# Altium schematic sheet Icarus Som.SchDoc("Icarus Som")
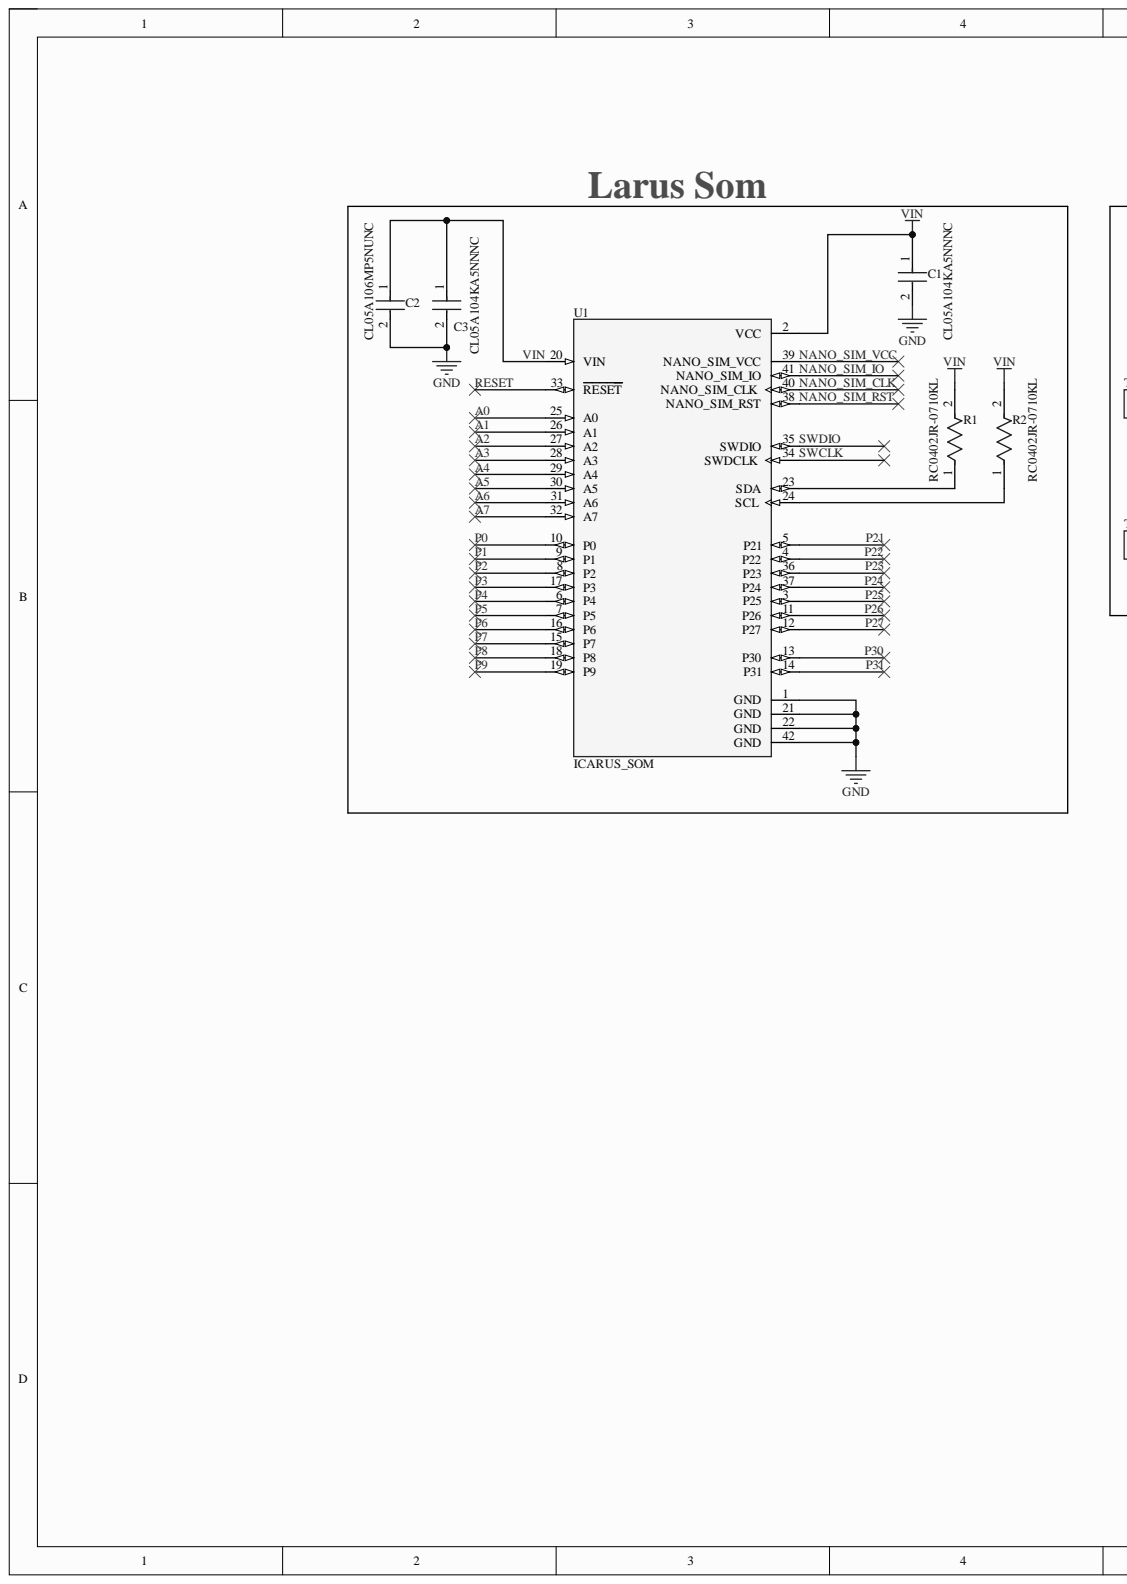
[diagram p0: Icarus Som.SchDoc, left]
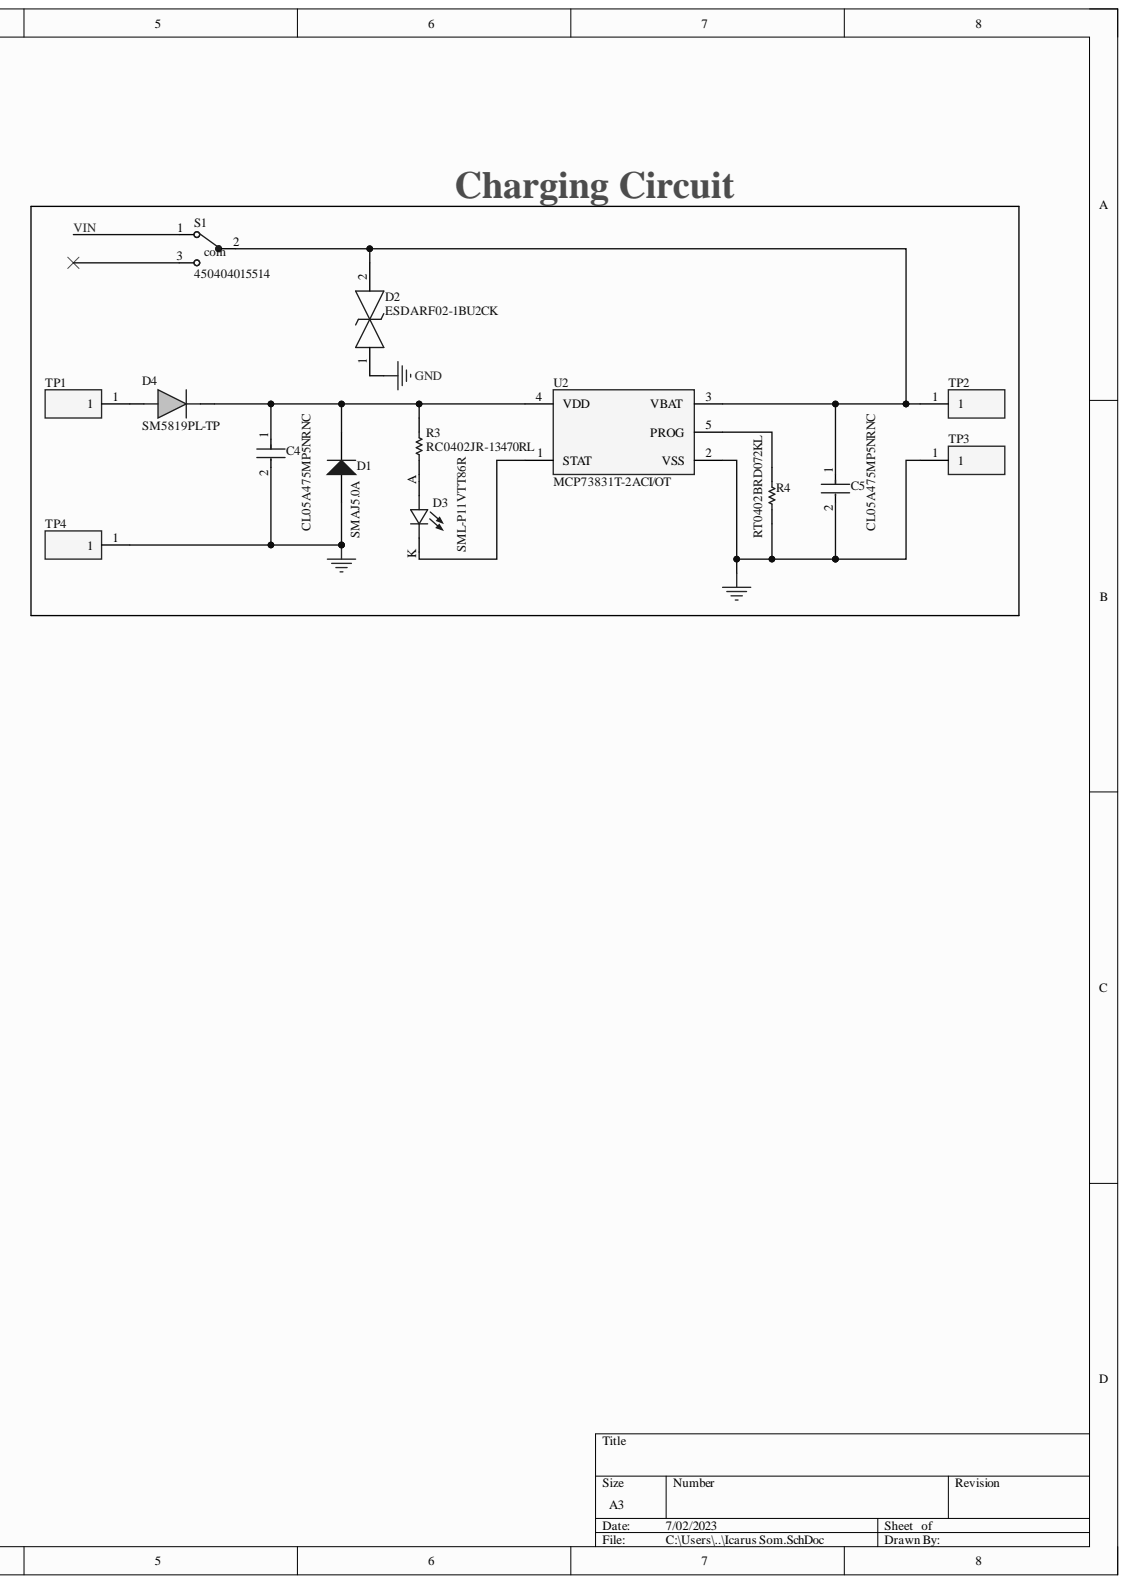
[diagram p1: Icarus Som.SchDoc, right]

## Parts
C1  CL05A104KA5NNNC  [FP-CL278-IPC_A]
C2  CL05A106MP5NUNC  [FP-CL05A106MP5NUNC-MFG]
C3  CL05A104KA5NNNC  [FP-CL278-IPC_A]
C4  CL05A475MP5NRNC  [FP-CL282-IPC_A]
C5  CL05A475MP5NRNC  [FP-CL282-IPC_A]
D1  SMAJ5.0A  [BOUR-SMA-2_V]
D2  ESDARF02-1BU2CK  [ESDARF021BU2CK]
D3  SML-P11VTT86R  [LED_SML-P11VTT86R]
D4  SM5819PL-TP  [SODFL3716X120N]
R1  RC0402JR-0710KL  [FP-RC0402-0_4-IPC_A]
R2  RC0402JR-0710KL  [FP-RC0402-0_4-IPC_A]
R3  RC0402JR-13470RL  [RESC1005X40X25LL05T10]
R4  RT0402BRD072KL  [RESC1005X35X25LL10T10]
S1  450404015514  [450404015514]
TP1  (no value)  [TP+195X110]
TP2  (no value)  [TP+195X110]
TP3  (no value)  [TP+195X110]
TP4  (no value)  [TP+195X110]
U1  ICARUS_SOM  [MODULE_ICARUS_SOM]
U2  MCP73831T-2ACI/OT  [FP-C04-091-OT-IPC_A]

## Nets
GND: C1.2 C2.2 C3.2 C4.2 C5.2 D1.1 D2.1 R4.1 TP3.1 TP4.1 U1.1(GND) U1.21(GND) U1.22(GND) U1.42(GND) U2.2(VSS)
A0: U1.25(A0)
A1: U1.26(A1)
A2: U1.27(A2)
A3: U1.28(A3)
A4: U1.29(A4)
A5: U1.30(A5)
A6: U1.31(A6)
A7: U1.32(A7)
NANO_SIM_CLK: U1.40(NANO_SIM_CLK)
NANO_SIM_IO: U1.41(NANO_SIM_IO)
NANO_SIM_RST: U1.38(NANO_SIM_RST)
NANO_SIM_VCC: U1.39(NANO_SIM_VCC)
P0: U1.10(P0)
P1: U1.9(P1)
P2: U1.8(P2)
P3: U1.17(P3)
P4: U1.6(P4)
P5: U1.7(P5)
P6: U1.16(P6)
P7: U1.15(P7)
P8: U1.18(P8)
P9: U1.19(P9)
P21: U1.5(P21)
P22: U1.4(P22)
P23: U1.36(P23)
P24: U1.37(P24)
P25: U1.3(P25)
P26: U1.11(P26)
P27: U1.12(P27)
P30: U1.13(P30)
P31: U1.14(P31)
RESET: U1.33(RESET)
SWCLK: U1.34(SWDCLK)
SWDIO: U1.35(SWDIO)
VIN: C1.1 C2.1 C3.1 R1.2 R2.2 S1.1 U1.2(VCC) U1.20(VIN)
NetC4_1: C4.1 D1.2 D4.1 R3.2 U2.4(VDD)
NetC5_1: C5.1 D2.2 S1.2 TP2.1 U2.3(VBAT)
NetD3_A: D3.A R3.1
NetD3_K: D3.K U2.1(STAT)
NetD4_2: D4.2 TP1.1
NetR1_1: R1.1 U1.23(SDA)
NetR2_1: R2.1 U1.24(SCL)
NetR4_2: R4.2 U2.5(PROG)

Not connected: S1 3

## Library data of the parts
C1 C3 = CMP-13271-000728-1: Cap Ceramic 100nF 25V X5R ±10% SMD 0402 +85°C Paper T/R; Capacitance: 100nF; Case Code (Imperial): 0402; Case Code (Metric): 1005; Case/Package: 0402; Component Kind: Standard; Depth: 0.5mm; Dielectric: X5R; Height: 0.5mm; Lead Free: Lead Free; Length: 1mm; Max Operating Temperature: 85°C; Min Operating Temperature: -55°C; Mount: Surface Mount; Package Quantity: 1; Packaging: Tape and Reel; Radiation Hardening: No; Thickness: 0.022inch; Tolerance: 10%; Voltage: 25V; Voltage Rating: 25V; Voltage Rating (DC): 25V; Width: 0.02inch; Library Name: Icarus Sch_Lib.SchLib
C2 = CMP-13271-000002-1: CAP CER 10UF 10V X5R 0402; Manufacturer: Samsung; Material: Ceramic; Temperature Coefficient: 15%; Tolerance: 20%; Automotive: No; Capacitance: 10uF; Category: Cap; Component Kind: Standard; ComponentLink2Description: Datasheet; ComponentLink2URL: http://www.samsungsem.com/kr/support/product-search/mlcc/__icsFiles/afieldfile/2019/05/10/19-CL05A106MP5NUNC.pdf; Device Class L1: Passive Components; Device Class L2: Capacitors; Device Class L3: Ceramic Capacitors; Digikey Description: CAP CER 10UF 10V X5R 0402; Height: 0.7mm; Ipc Land Pattern Name: CAPC10050X50; Lead Free: Yes; Manufacturer Part Number: CL05A106MP5NUNC; Octopart: https://octopart.com/cl05a106mp5nunc-samsung-22457395; Package: CL05A106MP5NUNC; RoHS: TRUE; Temperature: -55°C to +85°C; Temperature Characteristic: X5R; Temperature Range High: +85°C; Temperature Range Low: -55°C; Value: 10uF; Voltage Rating: 10V; Library Name: Icarus Sch_Lib.SchLib
C4 C5 = CMP-13271-000548-1: Cap Ceramic 4.7uF 10V X5R ±20% SMD 0402 +85°C Paper T/R; Capacitance: 4.7uF; Case Code (Imperial): 0402; Case Code (Metric): 1005; Case/Package: 0402; Component Kind: Standard; Dielectric: X5R; Lead Free: Lead Free; Length: 0.039inch; Max Operating Temperature: 85°C; Min Operating Temperature: -55°C; Mount: Surface Mount; Package Quantity: 10000; Packaging: Tape and Reel; Thickness: 0.022inch; Tolerance: 20%; Voltage Rating: 10V; Width: 0.02inch; Library Name: Icarus Sch_Lib.SchLib
D1 = CMP-2000-07090-1: SMAJ Transient Voltage Suppressor Diode Series, 3.5 V, -55 to 150 degC, 2-Pin SMA, RoHS, Tape and Reel; Manufacturer: Bourns; Catalog Drawings: SMAJSeriesFootprintSMAJ,SMBJSeries; Current - Peak Pulse (10/1000µs): 43.5A; EDA / CAD Models: DownloadfromAcceleratedDesigns; Featured Product: SMAJ/SMBJ/SMCJ/SMLJDiscreteTVSDiodes; Online Catalog: SMAJSeries; Other Names: SMAJ5.0ABDKR; Power - Peak Pulse: 400W; Power Line Protection: No; Type: Zener; Unidirectional Channels: 1; Voltage - Breakdown (Min): 6.4V; Voltage - Clamping (Max) @ Ipp: 9.2V; Voltage - Reverse Standoff (Typ): 5V; Applications: GeneralPurpose; Bidirectional Channels: -; Capacitance @ Frequency: -; Category: CircuitProtection; Component Kind: Standard; Datasheet URL: https://datasheet.ciiva.com/25624/0900766b8112213b-25624680.pdf; Datasheet Version: 08/2012; Family: TVS-Diodes; Manufacturer URL: http://www.bourns.com/; Mounting Technology: SurfaceMount; Mounting Type: SurfaceMount; Operating Temperature: -55°C~150°C(TJ); Package / Case: DO-214AC,SMA; Package Description: 2-Pin Surface Mount Device, Body 4.315 x 2.605 mm; Package Reference: SMA-2; Packaging: Digi-Reel®; RoHS Information: SMAJSeriesMaterialDeclaration; Series: SMAJ; Standard Package: 1; Supplier Device Package: SMA(DO-214AC); Library Name: Icarus Sch_Lib.SchLib
D2 = ESDARF02-1BU2CK: STMicroelectronics ESDARF02-1BU2CK Bi-Directional ESD Protection Diode, 20W peak, 2-Pin ST-0201; Geometry.Height: 0.33mm; Component Kind: Standard; Datasheet Link: https://www.st.com/resource/en/datasheet/esdarf02-1bu2ck.pdf; Manufacturer_Name: STMicroelectronics; Manufacturer_Part_Number: ESDARF02-1BU2CK; Mouser Part Number: 511-ESDARF02-1BU2CK; Mouser Price/Stock: https://www.mouser.co.uk/ProductDetail/STMicroelectronics/ESDARF02-1BU2CK?qs=Ng9CY5lTJpiKVTbvT0A0SQ%3D%3D; Library Name: Icarus Sch_Lib.SchLib
D3 = SML-P11VTT86R: Red 626nm LED Indication - Discrete 1.8V 0402 (1006 Metric); MF: Rohm; Check_prices: https://www.snapeda.com/parts/SML-P11VTT86R/Rohm/view-part/?ref=eda; Component Kind: Standard; Digi-Key_Part_Number: 846-SML-P11VTT86RTR-ND; MP: SML-P11VTT86R; Package: 0402 Rohm; Purchase-URL: https://pricing.snapeda.com/search?q=SML-P11VTT86R&ref=eda; SnapEDA_Link: https://www.snapeda.com/parts/SML-P11VTT86R/Rohm/view-part/?ref=snap; Library Name: Icarus Sch_Lib.SchLib
D4 = SM5819PL-TP: Schottky Barrier Rectifiers; Manufacturer_Name: MCC; Geometry.Height: 1.2mm; Component Kind: Standard; Datasheet Link: https://mccsemi.com/pdf/Products/SM5817PL-SM5819PL(SOD-123FL).pdf; Manufacturer_Part_Number: SM5819PL-TP; Mouser Part Number: 833-SM5819PL-TP; Mouser Price/Stock: https://www.mouser.co.uk/ProductDetail/Micro-Commercial-Components-MCC/SM5819PL-TP?qs=SdqRYZZ9IxAC1pyGL%2FvLRg%3D%3D; Library Name: Icarus Sch_Lib.SchLib
R1 R2 = CMP-2002-07915-3: RES 10K OHM 5% 1/16W 0402; Max Power Dissipation: 63mW; Power Rating: 62.5mW; Resistance: 10kR; Temperature Coefficient: 100ppm/°C; Voltage Rating: 50V; Case Code (Imperial): 0402; Case Code (Metric): 1005; Case/Package: 0402; Component Kind: Standard; Construction: Rectangular; Depth: 0.5mm; Features: Moisture Resistant; Height: 0.016inch; Lead Free: Lead Free; Length: 0.039inch; Max Operating Temperature: 155°C; Military Standard: Not; Min Operating Temperature: -55°C; Number of Terminations: 2; Package Quantity: 1; Packaging: Tape and Reel; Resistance Tolerance: 5%; Resistor Type: Thick Film; RoHS Compliant: Yes; Series: RC; Size Code: 0402; Termination: SMD/SMT; Tolerance: 5%; Width: 0.02inch; Library Name: Icarus Sch_Lib.SchLib
R3 = CMP-2002-08087-1: RES 470R; Manufacturer: Yageo; Component Kind: Standard; Part Number: RC0402JR-13470RL; Library Name: Icarus Sch_Lib.SchLib
R4 = CMP-2002-02941-1: RES 2K; Manufacturer: Yageo; Component Kind: Standard; Part Number: RT0402BRD072KL; Library Name: Icarus Sch_Lib.SchLib
S1 = 450404015514: MINI SLIDE SWITCH 6.7 X 2.7 MM.; MF: WÃ¼rth Elektronik; Availability: In Stock; Check_prices: https://www.snapeda.com/parts/450404015514/Wurth+Elektronik/view-part/?ref=eda; Component Kind: Standard; MP: 450404015514; Package: None; Price: None; Purchase-URL: https://pricing.snapeda.com/search/part/450404015514/?ref=eda; SnapEDA_Link: https://www.snapeda.com/parts/450404015514/Wurth+Elektronik/view-part/?ref=snap; Library Name: Icarus Sch_Lib.SchLib
TP1-TP4 = RCU-0C: Natural PC Test Point - - Plating Surface Mount Mounting Type; MF: TE Connectivity AMP Connectors; Availability: In Stock; Check_prices: https://www.snapeda.com/parts/RCU-0C/TE+Connectivity/view-part/?ref=eda; Component Kind: Standard; MP: RCU-0C; Package: None; Price: None; Purchase-URL: https://pricing.snapeda.com/search/part/RCU-0C/?ref=eda; SnapEDA_Link: https://www.snapeda.com/parts/RCU-0C/TE+Connectivity/view-part/?ref=snap; Library Name: Icarus Sch_Lib.SchLib
U1 = ICARUS_SOM: Module for IoT connectivity. Includes global LTE-M & NB-IoT, GPS, Accelerometer as well as an eSIM for connectivity out of the box.; MF: Actinius; MP: Icarus SOM; Availability: Not in stock; Component Kind: Standard; Library Name: Icarus Sch_Lib.SchLib; Package: None; Price: None
U2 = CMP-0133-00143-2: IC CONTROLLR LI-ION 4.2V SOT23-5; Drain to Source Resistance: 350mR; Max Charge Current: 500mA; Max Input Voltage: 6V; Max Output Voltage: 4.5V; Max Power Dissipation: 1.54W; Min Output Voltage: 4.168V; Min Supply Voltage: 3.75V; Number of Cells: 1; Voltage Rating: 4.534V; Case/Package: SOT-23; Component Kind: Standard; Contact Plating: Tin; Height: 0.051inch; Lead Free: Lead Free; Length: 0.122inch; Max Operating Temperature: 85°C; Max Supply Voltage: 6V; Min Operating Temperature: -40°C; Mount: Surface Mount; Number of Channels: 1; Number of Outputs: 1; Number of Pins: 5; Output Current: 500mA; Output Voltage: 4.2V; Packaging: Tape and Reel; Radiation Hardening: No; REACH SVHC: No SVHC; Termination: SMD/SMT; Weight: 0.00709oz; Width: 0.071inch; Library Name: Icarus Sch_Lib.SchLib
